# Altium schematic sheet MCU.SchDoc
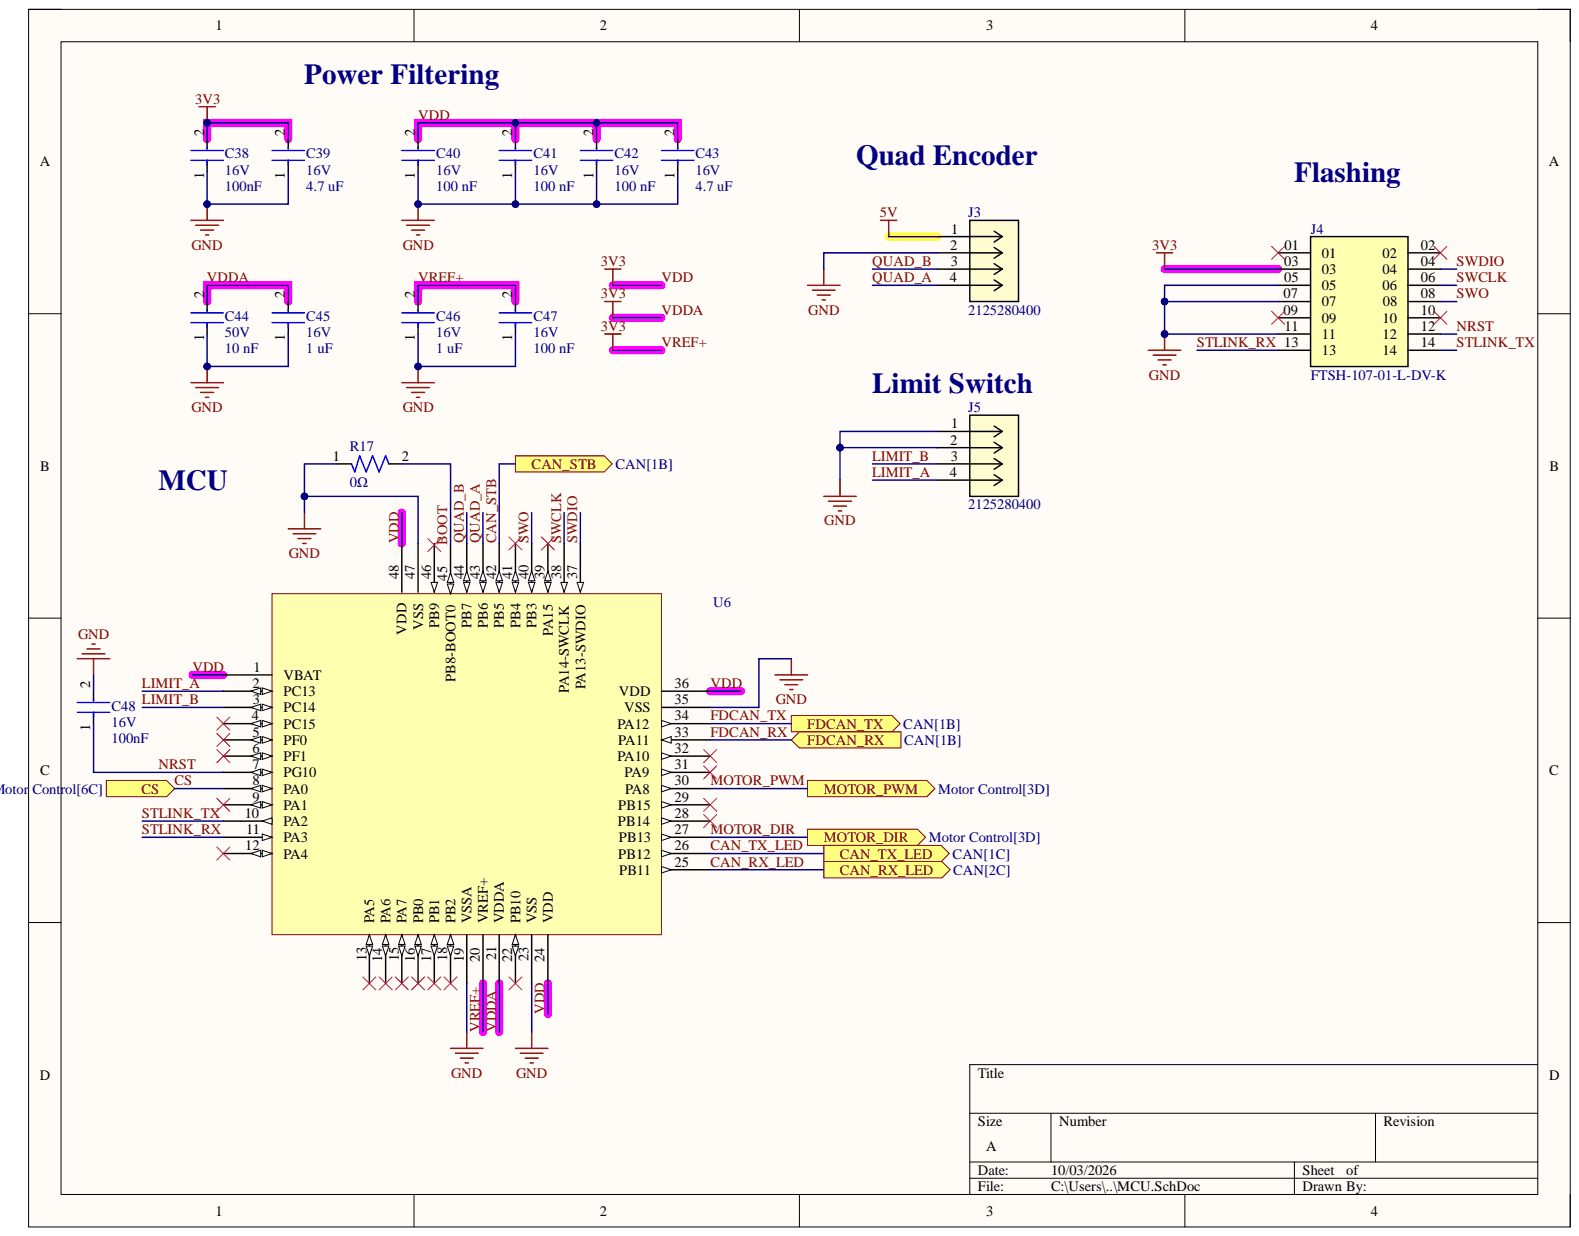
[diagram p0: MCU.SchDoc]

## Parts
C38  Capacitance: 100nF  Voltage Rating: 16V  [CAP-0805]  (hidden comment: CAP 0805)
C39  Capacitance: 4.7 uF  Voltage Rating: 16V  [CAP-0805]  (hidden comment: CAP 0805)
C40  Voltage Rating: 16V  [CAP-0805]  (hidden comment: CAP 0805)
C41  Capacitance: 100 nF  Voltage Rating: 16V  [CAP-0805]  (hidden comment: CAP 0805)
C42  Capacitance: 100 nF  Voltage Rating: 16V  [CAP-0805]  (hidden comment: CAP 0805)
C43  Capacitance: 4.7 uF  Voltage Rating: 16V  [CAP-0805]  (hidden comment: CAP 0805)
C44  Voltage Rating: 50V  [CAP-0805]  (hidden comment: CAP 0805)
C45  Capacitance: 1 uF  Voltage Rating: 16V  [CAP-0805]  (hidden comment: CAP 0805)
C46  Capacitance: 1 uF  Voltage Rating: 16V  [CAP-0805]  (hidden comment: CAP 0805)
C47  Voltage Rating: 16V  [CAP-0805]  (hidden comment: CAP 0805)
C48  Capacitance: 100nF  Voltage Rating: 16V  [CAP-0805]  (hidden comment: CAP 0805)
J3  2125280400  [CONN_2125280000_04_MOL]
J4  FTSH-107-01-L-DV-K  [SAMTEC_FTSH-107-01-L-DV-K]
J5  2125280400  [CONN_2125280000_04_MOL]
R17  Resistance: 0Ω  [RES-2010]  (hidden comment: CRF2010-J/-000ELF)
U6  STM32G431CBT6  [IC-LQFP48]  (value not drawn)

## Ports
CAN_RX_LED, CAN_STB, CAN_TX_LED, CS, FDCAN_RX, FDCAN_TX, MOTOR_DIR, MOTOR_PWM

## Nets
5V: J3.1
GND: C38.1 C39.1 C40.1 C41.1 C42.1 C43.1 C44.1 C45.1 C46.1 C47.1 C48.2 J3.2 J4.05 J4.07 J4.11 J5.1 J5.2 R17.1 U6.19(VSSA) U6.23(VSS) U6.35(VSS) U6.47(VSS)
BOOT: R17.2 U6.45(PB8-BOOT0)
CAN_RX_LED: U6.25(PB11) ; port CAN_RX_LED
CAN_STB: U6.42(PB5) ; port CAN_STB
CAN_TX_LED: U6.26(PB12) ; port CAN_TX_LED
CS: U6.8(PA0) ; port CS
FDCAN_RX: U6.33(PA11) ; port FDCAN_RX
FDCAN_TX: U6.34(PA12) ; port FDCAN_TX
LIMIT_A: J5.4 U6.2(PC13)
LIMIT_B: J5.3 U6.3(PC14)
MOTOR_DIR: U6.27(PB13) ; port MOTOR_DIR
MOTOR_PWM: U6.30(PA8) ; port MOTOR_PWM
NRST: C48.1 J4.12 U6.7(PG10)
QUAD_A: J3.4 U6.43(PB6)
QUAD_B: J3.3 U6.44(PB7)
STLINK_RX: J4.13 U6.11(PA3)
STLINK_TX: J4.14 U6.10(PA2)
SWCLK: J4.06 U6.38(PA14-SWCLK)
SWDIO: J4.04 U6.37(PA13-SWDIO)
SWO: J4.08 U6.40(PB3)
VDD: C38.2 C39.2 C40.2 C41.2 C42.2 C43.2 C44.2 C45.2 C46.2 C47.2 J4.03 U6.1(VBAT) U6.20(VREF+) U6.21(VDDA) U6.24(VDD) U6.36(VDD) U6.48(VDD)

Not connected: J4 01 02 09 10; U6 4(PC15) 5(PF0) 6(PF1) 9(PA1) 12(PA4) 13(PA5) 14(PA6) 15(PA7) 16(PB0) 17(PB1) 18(PB2) 22(PB10) 28(PB14) 29(PB15) 31(PA9) 32(PA10) 39(PA15) 41(PB4) 46(PB9)

## Library data of the parts
C40 C47 = CAP 0805: Capacitance: 100 nF; Component Kind: Standard; Library Name: EHW_27.IntLib
C44 = CAP 0805: Capacitance: 10 nF; Component Kind: Standard; Library Name: EHW_27.IntLib
J3 J5 = CONN MF+ 4 PIN Right Angle Square: Micro-Fit+ Right-Angle Header, Through Hole, 3.00mm Pitch, Dual Row, 4 Circuits, 1.52Âµm Matte Tin (Sn) Plating, Glow-Wire Capable, Black; Manufacturer_Name: Molex Connector Corporation; Component Kind: Standard; Manufacturer_Part_Number: 2125280400; RefDes: RefDes; Type: Type; Copyright: Copyright (C) 2026 Ultra Librarian. All rights reserved.; Library Name: EHW_27.IntLib
J4 = CONN_Flashing-0001: Connector Header Surface Mount 14 position 0.050" (1.27mm); MF: Samtec; Availability: Unavailable; Component Kind: Standard; ComponentLink1Description: Datasheet; ComponentLink1URL: https://mm.digikey.com/Volume0/opasdata/d220001/medias/docus/6209/ftsh-1xx-xx-xxx-dv-xxx-xxx-x-xx-mkt.pdf; MP: FTSH-107-01-L-DV-K; Package: None; Price: None; Library Name: EHW_27.IntLib
R17 = RES-0-0001: 0 Ohms Jumper Chip Resistor 2010 (5025 Metric) Automotive AEC-Q200 Metal Element; Tolerance: 1%; Component Kind: Standard; ComponentLink1Description: Datasheet; ComponentLink1URL: https://www.yageo.com/upload/media/product/products/datasheet/rchip/PYu-RC_Group_51_RoHS_L_12.pdf; Size: 0402; Library Name: EHW_27.IntLib
U6 = IC-STM32G431CBT6: IC MCU 32BIT 128KB FLASH 48LQFP; Component Kind: Standard; ComponentLink1Description: Datasheet; ComponentLink1URL: https://www.st.com/resource/en/datasheet/stm32g431cb.pdf; Package: 48LQFP; Library Name: EHW_27.IntLib
C38 C39 C41-C43 C45 C46 C48 = CAP 0805: Component Kind: Standard; Library Name: EHW_27.IntLib
